# Altium schematic sheet 温度检测.SchDoc
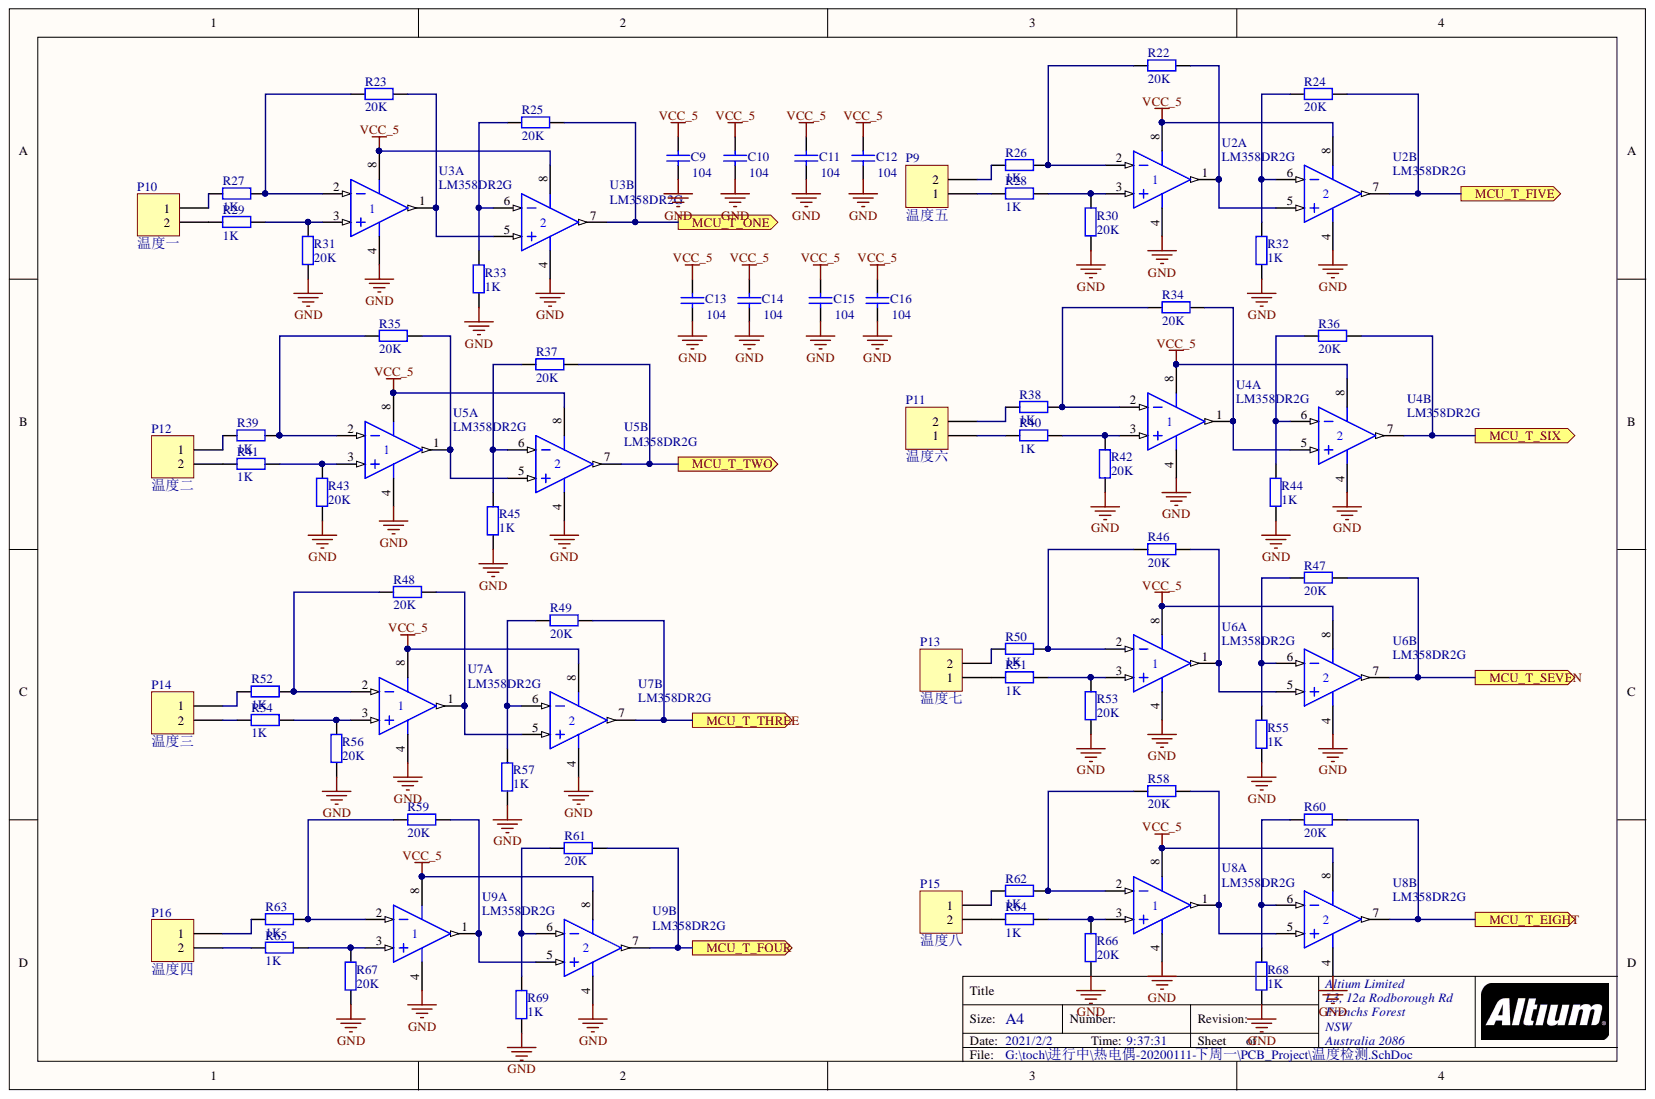
[diagram p0: 温度检测.SchDoc]

## Parts
C9  104  [0603_C]
C10  104  [0603_C]
C11  104  [0603_C]
C12  104  [0603_C]
C13  104  [0603_C]
C14  104  [0603_C]
C15  104  [0603_C]
C16  104  [0603_C]
P9  温度五  [CON1.5_2pin]
P10  温度一  [CON1.5_2pin]
P11  温度六  [CON1.5_2pin]
P12  温度二  [CON1.5_2pin]
P13  温度七  [CON1.5_2pin]
P14  温度三  [CON1.5_2pin]
P15  温度八  [CON1.5_2pin]
P16  温度四  [CON1.5_2pin]
R22  20K  [0603_R]
R23  20K  [0603_R]
R24  20K  [0603_R]
R25  20K  [0603_R]
R26  1K  [0603_R]
R27  1K  [0603_R]
R28  1K  [0603_R]
R29  1K  [0603_R]
R30  20K  [0603_R]
R31  20K  [0603_R]
R32  1K  [0603_R]
R33  1K  [0603_R]
R34  20K  [0603_R]
R35  20K  [0603_R]
R36  20K  [0603_R]
R37  20K  [0603_R]
R38  1K  [0603_R]
R39  1K  [0603_R]
R40  1K  [0603_R]
R41  1K  [0603_R]
R42  20K  [0603_R]
R43  20K  [0603_R]
R44  1K  [0603_R]
R45  1K  [0603_R]
R46  20K  [0603_R]
R47  20K  [0603_R]
R48  20K  [0603_R]
R49  20K  [0603_R]
R50  1K  [0603_R]
R51  1K  [0603_R]
R52  1K  [0603_R]
R53  20K  [0603_R]
R54  1K  [0603_R]
R55  1K  [0603_R]
R56  20K  [0603_R]
R57  1K  [0603_R]
R58  20K  [0603_R]
R59  20K  [0603_R]
R60  20K  [0603_R]
R61  20K  [0603_R]
R62  1K  [0603_R]
R63  1K  [0603_R]
R64  1K  [0603_R]
R65  1K  [0603_R]
R66  20K  [0603_R]
R67  20K  [0603_R]
R68  1K  [0603_R]
R69  1K  [0603_R]
U2  LM358DR2G  [SOIC-8_150mil]  (parts A,B)
U3  LM358DR2G  [SOIC-8_150mil]  (parts A,B)
U4  LM358DR2G  [SOIC-8_150mil]  (parts A,B)
U5  LM358DR2G  [SOIC-8_150mil]  (parts A,B)
U6  LM358DR2G  [SOIC-8_150mil]  (parts A,B)
U7  LM358DR2G  [SOIC-8_150mil]  (parts A,B)
U8  LM358DR2G  [SOIC-8_150mil]  (parts A,B)
U9  LM358DR2G  [SOIC-8_150mil]  (parts A,B)

## Ports
MCU_T_EIGHT, MCU_T_FIVE, MCU_T_FOUR, MCU_T_ONE, MCU_T_SEVEN, MCU_T_SIX, MCU_T_THREE, MCU_T_TWO

## Nets
GND: C9.2 C10.2 C11.2 C12.2 C13.2 C14.2 C15.2 C16.2 R30.2 R31.2 R32.1 R33.1 R42.2 R43.2 R44.1 R45.1 R53.2 R55.1 R56.2 R57.1 R66.2 R67.2 R68.1 R69.1 U2.4 U3.4 U4.4 U5.4 U6.4 U7.4 U8.4 U9.4
VCC_5: C9.1 C10.1 C11.1 C12.1 C13.1 C14.1 C15.1 C16.1 U2.8 U3.8 U4.8 U5.8 U6.8 U7.8 U8.8 U9.8
MCU_T_EIGHT: R60.2 U8.7 ; port MCU_T_EIGHT
MCU_T_FIVE: R24.2 U2.7 ; port MCU_T_FIVE
MCU_T_FOUR: R61.2 U9.7 ; port MCU_T_FOUR
MCU_T_ONE: R25.2 U3.7 ; port MCU_T_ONE
MCU_T_SEVEN: R47.2 U6.7 ; port MCU_T_SEVEN
MCU_T_SIX: R36.2 U4.7 ; port MCU_T_SIX
MCU_T_THREE: R49.2 U7.7 ; port MCU_T_THREE
MCU_T_TWO: R37.2 U5.7 ; port MCU_T_TWO
NetP9_1: P9.1 R28.1
NetP9_2: P9.2 R26.1
NetP10_1: P10.1 R27.1
NetP10_2: P10.2 R29.1
NetP11_1: P11.1 R40.1
NetP11_2: P11.2 R38.1
NetP12_1: P12.1 R39.1
NetP12_2: P12.2 R41.1
NetP13_1: P13.1 R51.1
NetP13_2: P13.2 R50.1
NetP14_1: P14.1 R52.1
NetP14_2: P14.2 R54.1
NetP15_1: P15.1 R62.1
NetP15_2: P15.2 R64.1
NetP16_1: P16.1 R63.1
NetP16_2: P16.2 R65.1
NetR22_1: R22.1 R26.2 U2.2
NetR22_2: R22.2 U2.1 U2.5
NetR23_1: R23.1 R27.2 U3.2
NetR23_2: R23.2 U3.1 U3.5
NetR24_1: R24.1 R32.2 U2.6
NetR25_1: R25.1 R33.2 U3.6
NetR28_2: R28.2 R30.1 U2.3
NetR29_2: R29.2 R31.1 U3.3
NetR34_1: R34.1 R38.2 U4.2
NetR34_2: R34.2 U4.1 U4.5
NetR35_1: R35.1 R39.2 U5.2
NetR35_2: R35.2 U5.1 U5.5
NetR36_1: R36.1 R44.2 U4.6
NetR37_1: R37.1 R45.2 U5.6
NetR40_2: R40.2 R42.1 U4.3
NetR41_2: R41.2 R43.1 U5.3
NetR46_1: R46.1 R50.2 U6.2
NetR46_2: R46.2 U6.1 U6.5
NetR47_1: R47.1 R55.2 U6.6
NetR48_1: R48.1 R52.2 U7.2
NetR48_2: R48.2 U7.1 U7.5
NetR49_1: R49.1 R57.2 U7.6
NetR51_2: R51.2 R53.1 U6.3
NetR54_2: R54.2 R56.1 U7.3
NetR58_1: R58.1 R62.2 U8.2
NetR58_2: R58.2 U8.1 U8.5
NetR59_1: R59.1 R63.2 U9.2
NetR59_2: R59.2 U9.1 U9.5
NetR60_1: R60.1 R68.2 U8.6
NetR61_1: R61.1 R69.2 U9.6
NetR64_2: R64.2 R66.1 U8.3
NetR65_2: R65.2 R67.1 U9.3

## Library data of the parts
C9-C16 = 0603 1uF (105) 10% 50V: 贴片电容; Component Kind: Standard; ComponentLink1Description: 供应商链接; ComponentLink1URL: http://www.szlcsc.com/product/details_16531.html; Library Name: Miscellaneous Devices LC.IntLib; Package: 0603; Supplier: LC; SuppliersPartNumber: C15849
P9-P16 = Header 2: Header, 2-Pin; Component Kind: Standard; LatestRevisionDate: 17-Jul-2002; LatestRevisionNote: Re-released for DXP Platform.; Library Name: Miscellaneous Connectors.IntLib; Signal Integrity: Connector; Publisher: Altium Limited
R22-R69 = 0603 10KΩ (1002) 1%: 贴片电阻; Component Kind: Standard; ComponentLink1Description: 供应商链接; ComponentLink1URL: http://www.szlcsc.com/product/details_26547.html; Library Name: Miscellaneous Devices LC.IntLib; Package: 0603; Supplier: LC; SuppliersPartNumber: C25804
U2-U9 = LM358DR2G_[C7950]: 通用运放; Component Kind: Standard; ComponentLink1Description: 供应商链接; ComponentLink1URL: http://www.szlcsc.com/product/details_8427.html; Library Name: Miscellaneous Devices LC.IntLib; Package: SOIC-8; Supplier: LC; SuppliersPartNumber: C7950
